# Altium schematic sheet signals.SchDoc(signals)
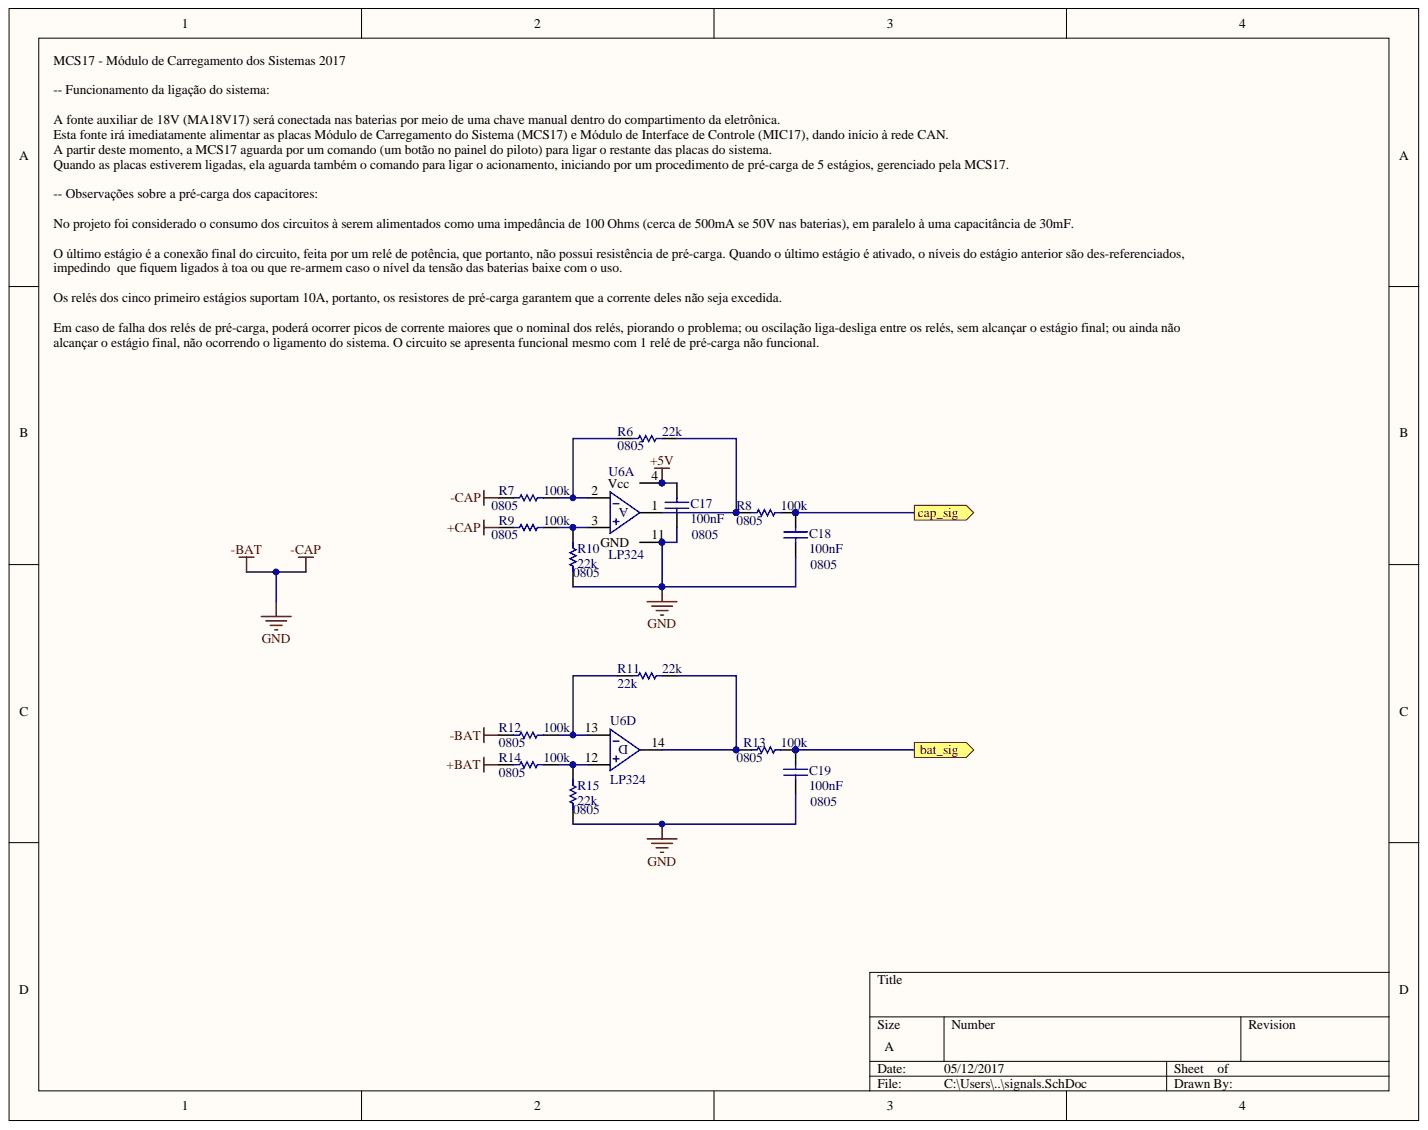
[diagram p0: signals.SchDoc]

## Parts
C17  100nF  [0805]
C18  100nF  [0805]
C19  100nF  [0805]
R6  22k  Type: 0805  [0805R]
R7  100k  Type: 0805  [0805R]
R8  100k  Type: 0805  [0805R]
R9  100k  Type: 0805  [0805R]
R10  22k  Type: 0805  [0805R]
R11  22k  [0805R]
R12  100k  Type: 0805  [0805R]
R13  100k  Type: 0805  [0805R]
R14  100k  Type: 0805  [0805R]
R15  22k  Type: 0805  [0805R]
U6  LP324  [SOIC14-150(50)]  (parts A,D)

## Ports
BAT_SIG, CAP_SIG

## Nets
+5V: C17.2 U6.4(Vcc)
+BAT: R14.2
+CAP: R9.2
-BAT: C17.1 C18.1 C19.1 R7.2 R10.2 R12.2 R15.2 U6.11(GND)
NetC18_2: C18.2 R8.1 ; port CAP_SIG
NetC19_2: C19.2 R13.1 ; port BAT_SIG
NetR6_1: R6.1 R8.2 U6.1(A)
NetR6_2: R6.2 R7.1 U6.2
NetR9_1: R9.1 R10.1 U6.3
NetR11_1: R11.1 R13.2 U6.14(D)
NetR11_2: R11.2 R12.1 U6.13
NetR14_1: R14.1 R15.1 U6.12

## Library data of the parts
C17-C19 = Cap: Capacitor; Type: pth; Voltage: 25V; Component Kind: Standard; Library Name: Miscellaneous Devices.IntLib; Simulation: CAP; Value: 100nF
R6-R15 = Res1: Resistor; Component Kind: Standard; Library Name: Miscellaneous Devices.IntLib; Simulation: RESISTOR
  R6 R10 R15: Value: 22k
  R7-R9 R12-R14: Value: 100k
  R11: Type: 0805; Value: 22k
U6 = LM324: Quadruple Operational Amplifier; Component Kind: Standard; Value: LP324; Library Name: R2R - Nova.intlib
